# Altium schematic sheet S06S-Controller.SchDoc
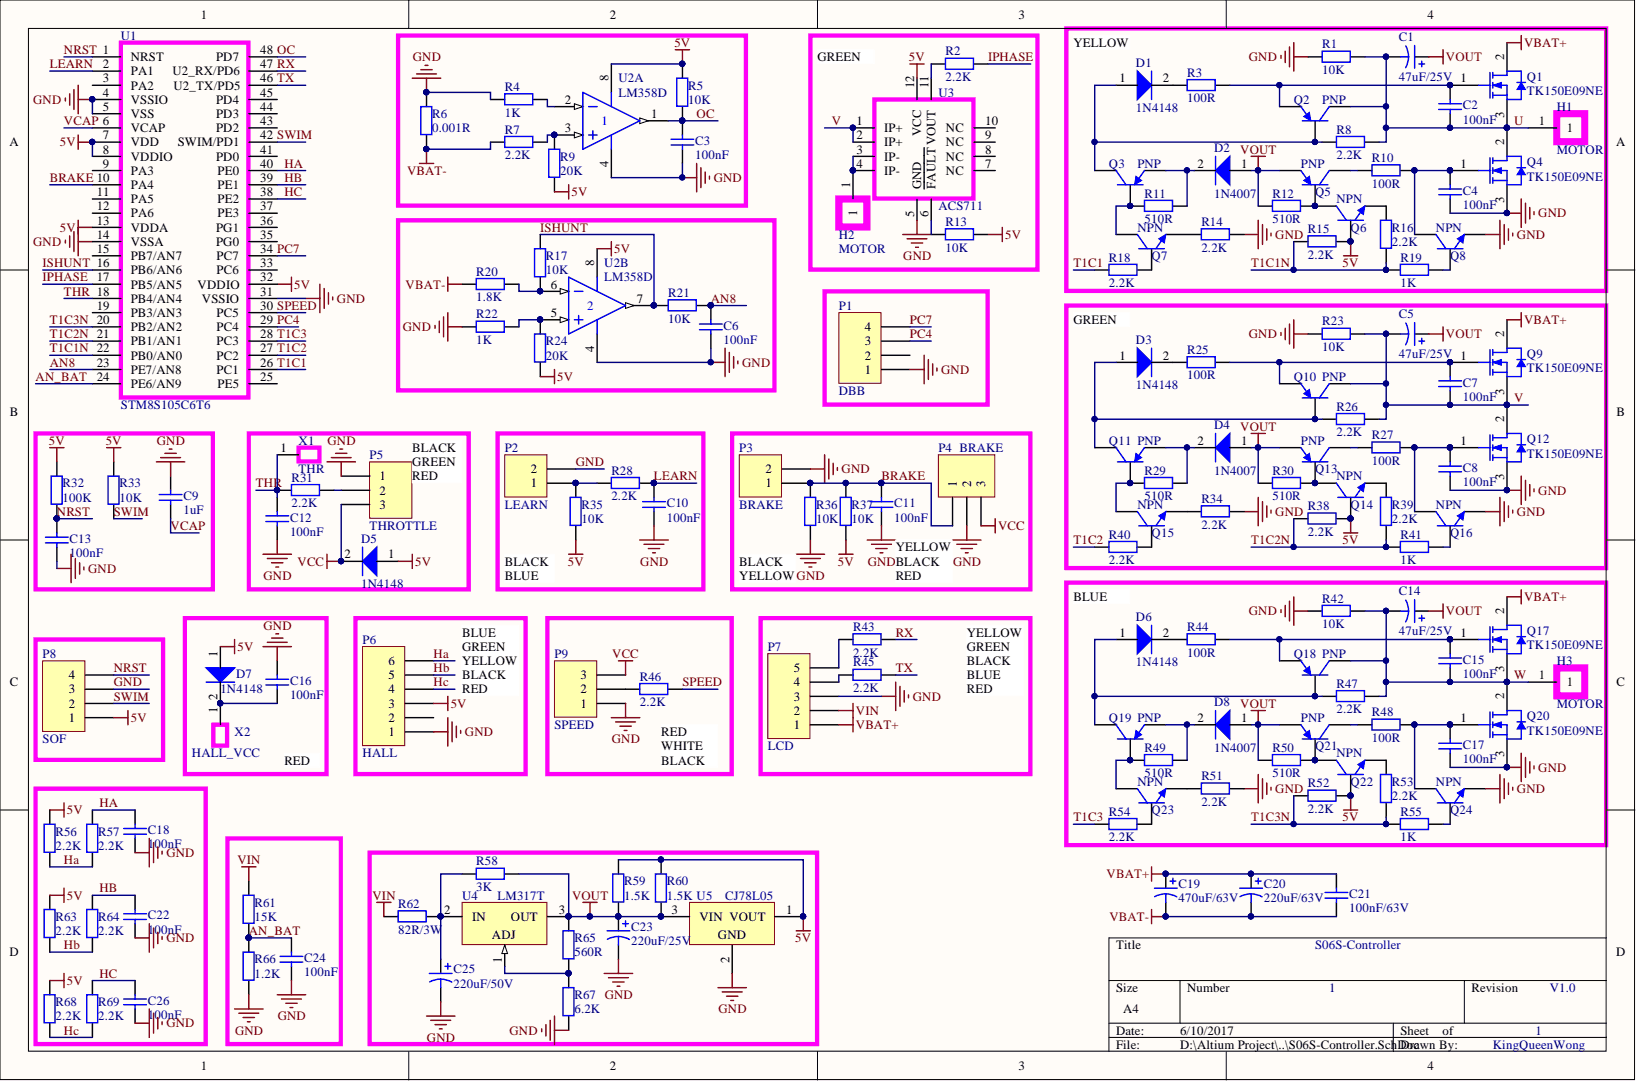
[diagram p0: S06S-Controller.SchDoc]

## Parts
C1  value 47uF/25V  [RB7.6-15]  (hidden comment: Cap Pol1)
C2  value 100nF  [0603C]  (hidden comment: Cap)
C3  value 100nF  [0603C]  (hidden comment: Cap)
C4  value 100nF  [0603C]  (hidden comment: Cap)
C5  value 47uF/25V  [RB7.6-15]  (hidden comment: Cap Pol1)
C6  value 100nF  [0603C]  (hidden comment: Cap)
C7  value 100nF  [0603C]  (hidden comment: Cap)
C8  value 100nF  [0603C]  (hidden comment: Cap)
C9  value 1uF  [0603C]  (hidden comment: Cap)
C10  value 100nF  [0603C]  (hidden comment: Cap)
C11  value 100nF  [0603C]  (hidden comment: Cap)
C12  value 100nF  [0603C]  (hidden comment: Cap)
C13  value 100nF  [0603C]  (hidden comment: Cap)
C14  value 47uF/25V  [RB7.6-15]  (hidden comment: Cap Pol1)
C15  value 100nF  [0603C]  (hidden comment: Cap)
C16  value 100nF  [0603C]  (hidden comment: Cap)
C17  value 100nF  [0603C]  (hidden comment: Cap)
C18  value 100nF  [0603C]  (hidden comment: Cap)
C19  value 470uF/63V  [RB7.6-15]  (hidden comment: Cap Pol1)
C20  value 220uF/63V  [RB7.6-15]  (hidden comment: Cap Pol1)
C21  value 100nF/63V  [0603C]  (hidden comment: Cap)
C22  value 100nF  [0603C]  (hidden comment: Cap)
C23  value 220uF/25V  [RB7.6-15]  (hidden comment: Cap Pol1)
C24  value 100nF  [0603C]  (hidden comment: Cap)
C25  value 220uF/50V  [RB7.6-15]  (hidden comment: Cap Pol1)
C26  value 100nF  [0603C]  (hidden comment: Cap)
D1  1N4148  [SOD80C]
D2  1N4007  [SOD80C]
D3  1N4148  [SOD80C]
D4  1N4007  [SOD80C]
D5  1N4148  [SOD80C]
D6  1N4148  [SOD80C]
D7  1N4148  [SOD80C]
D8  1N4007  [SOD80C]
H1  MOTOR  [MOTOR]
H2  MOTOR  [MOTOR]
H3  MOTOR  [MOTOR]
P1  DBB  [HDR1X4]
P2  LEARN  [HDR1X2]
P3  BRAKE  [HDR1X2]
P4  BRAKE  [HDR1X3]
P5  THROTTLE  [HDR1X3]
P6  HALL  [HDR1X6]
P7  LCD  [HDR1X5]
P8  SOF  [HDR1X4]
P9  SPEED  [HDR1X3]
Q1  TK150E09NE  [TO220ABN]
Q2  PNP  [SOT23]
Q3  PNP  [SOT23]
Q4  TK150E09NE  [TO220ABN]
Q5  PNP  [SOT23]
Q6  NPN  [SOT23]
Q7  NPN  [SOT23]
Q8  NPN  [SOT23]
Q9  TK150E09NE  [TO220ABN]
Q10  PNP  [SOT23]
Q11  PNP  [SOT23]
Q12  TK150E09NE  [TO220ABN]
Q13  PNP  [SOT23]
Q14  NPN  [SOT23]
Q15  NPN  [SOT23]
Q16  NPN  [SOT23]
Q17  TK150E09NE  [TO220ABN]
Q18  PNP  [SOT23]
Q19  PNP  [SOT23]
Q20  TK150E09NE  [TO220ABN]
Q21  PNP  [SOT23]
Q22  NPN  [SOT23]
Q23  NPN  [SOT23]
Q24  NPN  [SOT23]
R1  value 10K  [0603R]  (hidden comment: Res2)
R2  value 2.2K  [0603R]  (hidden comment: Res2)
R3  value 100R  [0603R]  (hidden comment: Res2)
R4  value 1K  [0603R]  (hidden comment: Res2)
R5  value 10K  [0603R]  (hidden comment: Res2)
R6  value 0.001R  [0603R]  (hidden comment: Res2)
R7  value 2.2K  [0603R]  (hidden comment: Res2)
R8  value 2.2K  [0603R]  (hidden comment: Res2)
R9  value 20K  [0603R]  (hidden comment: Res2)
R10  value 100R  [0603R]  (hidden comment: Res2)
R11  value 510R  [0603R]  (hidden comment: Res2)
R12  value 510R  [0603R]  (hidden comment: Res2)
R13  value 10K  [0603R]  (hidden comment: Res2)
R14  value 2.2K  [0603R]  (hidden comment: Res2)
R15  value 2.2K  [0603R]  (hidden comment: Res2)
R16  value 2.2K  [0603R]  (hidden comment: Res2)
R17  value 10K  [0603R]  (hidden comment: Res2)
R18  value 2.2K  [0603R]  (hidden comment: Res2)
R19  value 1K  [0603R]  (hidden comment: Res2)
R20  value 1.8K  [0603R]  (hidden comment: Res2)
R21  value 10K  [0603R]  (hidden comment: Res2)
R22  value 1K  [0603R]  (hidden comment: Res2)
R23  value 10K  [0603R]  (hidden comment: Res2)
R24  value 20K  [0603R]  (hidden comment: Res2)
R25  value 100R  [0603R]  (hidden comment: Res2)
R26  value 2.2K  [0603R]  (hidden comment: Res2)
R27  value 100R  [0603R]  (hidden comment: Res2)
R28  value 2.2K  [0603R]  (hidden comment: Res2)
R29  value 510R  [0603R]  (hidden comment: Res2)
R30  value 510R  [0603R]  (hidden comment: Res2)
R31  value 2.2K  [0603R]  (hidden comment: Res2)
R32  value 100K  [0603R]  (hidden comment: Res2)
R33  value 10K  [0603R]  (hidden comment: Res2)
R34  value 2.2K  [0603R]  (hidden comment: Res2)
R35  value 10K  [0603R]  (hidden comment: Res2)
R36  value 10K  [0603R]  (hidden comment: Res2)
R37  value 10K  [0603R]  (hidden comment: Res2)
R38  value 2.2K  [0603R]  (hidden comment: Res2)
R39  value 2.2K  [0603R]  (hidden comment: Res2)
R40  value 2.2K  [0603R]  (hidden comment: Res2)
R41  value 1K  [0603R]  (hidden comment: Res2)
R42  value 10K  [0603R]  (hidden comment: Res2)
R43  value 2.2K  [0603R]  (hidden comment: Res2)
R44  value 100R  [0603R]  (hidden comment: Res2)
R45  value 2.2K  [0603R]  (hidden comment: Res2)
R46  value 2.2K  [0603R]  (hidden comment: Res2)
R47  value 2.2K  [0603R]  (hidden comment: Res2)
R48  value 100R  [0603R]  (hidden comment: Res2)
R49  value 510R  [0603R]  (hidden comment: Res2)
R50  value 510R  [0603R]  (hidden comment: Res2)
R51  value 2.2K  [0603R]  (hidden comment: Res2)
R52  value 2.2K  [0603R]  (hidden comment: Res2)
R53  value 2.2K  [0603R]  (hidden comment: Res2)
R54  value 2.2K  [0603R]  (hidden comment: Res2)
R55  value 1K  [0603R]  (hidden comment: Res2)
R56  value 2.2K  [0603R]  (hidden comment: Res2)
R57  value 2.2K  [0603R]  (hidden comment: Res2)
R58  value 3K  [0603R]  (hidden comment: Res2)
R59  value 1.5K  [0603R]  (hidden comment: Res2)
R60  value 1.5K  [0603R]  (hidden comment: Res2)
R61  value 15K  [0603R]  (hidden comment: Res2)
R62  value 82R/3W  [0603R]  (hidden comment: Res2)
R63  value 2.2K  [0603R]  (hidden comment: Res2)
R64  value 2.2K  [0603R]  (hidden comment: Res2)
R65  value 560R  [0603R]  (hidden comment: Res2)
R66  value 1.2K  [0603R]  (hidden comment: Res2)
R67  value 6.2K  [0603R]  (hidden comment: Res2)
R68  value 2.2K  [0603R]  (hidden comment: Res2)
R69  value 2.2K  [0603R]  (hidden comment: Res2)
U1  STM8S105C6T6  [TQFP-48]
U2  LM358D  [751-02_N]  (parts A,B)
U3  ACS711  [QFN12]
U4  LM317T  [TO220ABN]
U5  CJ78L05  [TO-92]
X1  THR  [HDR1X1]
X2  HALL_VCC  [HDR1X1]

## Nets
5V: D5.1 D7.1 P6.3 P8.1 Q6.2 Q14.2 Q22.2 R5.2 R9.1 R13.1 R15.2 R24.1 R32.1 R33.1 R35.1 R37.1 R38.2 R52.2 R56.1 R59.2 R60.2 R63.1 R68.1 U1.7(VDD) U1.8(VDDIO) U1.13(VDDA) U1.32(VDDIO) U2.8 U3.12(VCC) U5.1
VBAT+: C19.1 C20.1 C21.1 P7.1 Q1.2 Q9.2 Q17.2
VBAT-: C19.2 C20.2 C21.2 R6.2 R7.2 R20.2
VCC: D5.2 P4.3 P5.3 P9.3
VIN: P7.2 R61.2 R62.1
VOUT: C1.1 C5.1 C14.1 C23.1 D2.1 D4.1 D8.1 Q5.3 Q13.3 Q21.3 R12.2 R30.2 R50.2 R58.1 R59.1 R60.1 R65.1 U4.3 U5.3
AN8: C6.1 R21.2 U1.23(PE7/AN8)
AN_BAT: C24.1 R61.1 R66.2 U1.24(PE6/AN9)
BRAKE: C11.1 P3.1 P4.1 R36.2 R37.2 U1.10(PA4)
GND: C3.2 C4.2 C6.2 C8.2 C9.1 C10.2 C11.2 C12.2 C13.2 C16.2 C17.2 C18.2 C22.2 C23.2 C24.2 C25.2 C26.2 P1.1 P2.2 P3.2 P4.2 P5.1 P6.1 P7.3 P8.3 P9.1 Q4.3 Q8.3 Q12.3 Q16.3 Q20.3 Q24.3 R1.2 R4.2 R6.1 R14.1 R22.2 R23.2 R34.1 R36.1 R42.2 R51.1 R66.1 R67.2 U1.4(VSSIO) U1.5(VSS) U1.14(VSSA) U1.31(VSSIO) U2.4 U3.5(GND) U5.2
Ha: C18.1 P6.6 R56.2 R57.1 R57.2 U1.40(PE0)
Hb: C22.1 P6.5 R63.2 R64.1 R64.2 U1.39(PE1)
Hc: C26.1 P6.4 R68.2 R69.1 R69.2 U1.38(PE2)
IPHASE: R2.1 U1.17(PB5/AN5)
ISHUNT: R17.2 R21.1 U1.16(PB6/AN6) U2.7
LEARN: C10.1 R28.2 U1.2(PA1)
NRST: C13.1 P8.4 R32.2 U1.1(NRST)
OC: C3.1 R5.1 U1.48(PD7) U2.1
PC4: P1.3 U1.29(PC4)
PC7: P1.4 U1.34(PC7)
RX: R43.2 U1.47(U2_RX/PD6)
SPEED: R46.2 U1.30(PC5)
SWIM: P8.2 R33.2 U1.42(SWIM/PD1)
T1C1: R18.1 U1.26(PC1)
T1C1N: R15.1 R16.1 R19.1 U1.22(PB0/AN0)
T1C2: R40.1 U1.27(PC2)
T1C2N: R38.1 R39.1 R41.1 U1.21(PB1/AN1)
T1C3: R54.1 U1.28(PC3)
T1C3N: R52.1 R53.1 R55.1 U1.20(PB2/AN2)
THR: C12.1 R31.1 U1.18(PB4/AN4) X1.1
TX: R45.2 U1.46(U2_TX/PD5)
U: C1.2 C2.2 H1.1 Q1.3 Q2.1 Q4.2 R1.1 R8.2
V: C5.2 C7.2 Q9.3 Q10.1 Q12.2 R23.1 R26.2 U3.1(IP+) U3.2(IP+)
VCAP: C9.2 U1.6(VCAP)
W: C14.2 C15.2 H3.1 Q17.3 Q18.1 Q20.2 R42.1 R47.2
NetC2_1: C2.1 Q1.1 Q2.3 R3.1
NetC4_1: C4.1 Q4.1 Q8.1 R10.1
NetC7_1: C7.1 Q9.1 Q10.3 R25.1
NetC8_1: C8.1 Q12.1 Q16.1 R27.1
NetC15_1: C15.1 Q17.1 Q18.3 R44.1
NetC16_1: C16.1 D7.2 X2.1
NetC17_1: C17.1 Q20.1 Q24.1 R48.1
NetC25_1: C25.1 R58.2 R62.2 U4.2
NetD1_1: D1.1 Q2.2 Q3.1 R8.1
NetD1_2: D1.2 R3.2
NetD2_2: D2.2 Q3.3 R11.1
NetD3_1: D3.1 Q10.2 Q11.1 R26.1
NetD3_2: D3.2 R25.2
NetD4_2: D4.2 Q11.3 R29.1
NetD6_1: D6.1 Q18.2 Q19.1 R47.1
NetD6_2: D6.2 R44.2
NetD8_2: D8.2 Q19.3 R49.1
NetH2_1: H2.1 U3.3(IP-) U3.4(IP-)
NetP2_1: P2.1 R28.1 R35.2
NetP5_2: P5.2 R31.2
NetP7_4: P7.4 R45.1
NetP7_5: P7.5 R43.1
NetP9_2: P9.2 R46.1
NetQ3_2: Q3.2 Q7.1 R11.2
NetQ5_1: Q5.1 R10.2
NetQ5_2: Q5.2 Q6.1 R12.1
NetQ6_3: Q6.3 R16.2
NetQ7_2: Q7.2 R18.2
NetQ7_3: Q7.3 R14.2
NetQ8_2: Q8.2 R19.2
NetQ11_2: Q11.2 Q15.1 R29.2
NetQ13_1: Q13.1 R27.2
NetQ13_2: Q13.2 Q14.1 R30.1
NetQ14_3: Q14.3 R39.2
NetQ15_2: Q15.2 R40.2
NetQ15_3: Q15.3 R34.2
NetQ16_2: Q16.2 R41.2
NetQ19_2: Q19.2 Q23.1 R49.2
NetQ21_1: Q21.1 R48.2
NetQ21_2: Q21.2 Q22.1 R50.1
NetQ22_3: Q22.3 R53.2
NetQ23_2: Q23.2 R54.2
NetQ23_3: Q23.3 R51.2
NetQ24_2: Q24.2 R55.2
NetR2_2: R2.2 U3.11(VOUT)
NetR4_1: R4.1 U2.2
NetR7_1: R7.1 R9.2 U2.3
NetR13_2: R13.2 U3.6(FAULT)
NetR17_1: R17.1 R20.1 U2.6
NetR22_1: R22.1 R24.2 U2.5
NetR65_2: R65.2 R67.1 U4.1

Not connected: P1 2; P6 2; U1 3(PA2) 9(PA3) 11(PA5) 12(PA6) 15(PB7/AN7) 19(PB3/AN3) 25(PE5) 33(PC6) 35(PG0) 36(PG1) 37(PE3) 41(PD0) 43(PD2) 44(PD3) 45(PD4); U3 7(NC) 8(NC) 9(NC) 10(NC)

## Library data of the parts
C1 C5 C14 C19 C20 C23 C25 = Cap Pol1: Polarized Capacitor (Radial); Component Kind: Standard; LatestRevisionDate: 17-Jul-2002; LatestRevisionNote: Re-released for DXP Platform.; Library Name: Miscellaneous Devices.IntLib; PackageDescription: Polarized Capacitor (Radial); 2 Leads; PackageReference: RB7.6-15; Published: 8-Jun-2000; Signal Integrity: Cap Pol; Simulation: CAP; Publisher: Altium Limited
C2-C4 C6-C13 C15-C18 C21 C22 C24 C26 = Cap: Capacitor; Component Kind: Standard; LatestRevisionDate: 17-Jul-2002; LatestRevisionNote: Re-released for DXP Platform.; Library Name: Miscellaneous Devices.IntLib; PackageDescription: Radial Cap, Thru-Hole; 2 Leads; 0.3 in Pin Spacing; PackageReference: RAD-0.3; Published: 8-Jun-2000; Signal Integrity: Cap; Simulation: CAP; Publisher: Altium Limited
D2 D4 D8 = 1N4148: Component Kind: Standard; Description: 1N4148; Library Name: Schlib.SchLib
P1 P8 = Header 4: Header, 4-Pin; Component Kind: Standard; LatestRevisionDate: 17-Jul-2002; LatestRevisionNote: Re-released for DXP Platform.; Library Name: Miscellaneous Connectors.IntLib; Signal Integrity: Connector; Publisher: Altium Limited
P2 P3 = Header 2: Header, 2-Pin; Component Kind: Standard; LatestRevisionDate: 17-Jul-2002; LatestRevisionNote: Re-released for DXP Platform.; Library Name: Miscellaneous Connectors.IntLib; Signal Integrity: Connector; Publisher: Altium Limited
P4 P5 P9 = Header 3: Header, 3-Pin; Component Kind: Standard; LatestRevisionDate: 17-Jul-2002; LatestRevisionNote: Re-released for DXP Platform.; Library Name: Miscellaneous Connectors.IntLib; Signal Integrity: Connector; Publisher: Altium Limited
P6 = Header 6: Header, 6-Pin; Component Kind: Standard; LatestRevisionDate: 17-Jul-2002; LatestRevisionNote: Re-released for DXP Platform.; Library Name: Miscellaneous Connectors.IntLib; Signal Integrity: Connector; Publisher: Altium Limited
P7 = Header 5: Header, 5-Pin; Component Kind: Standard; LatestRevisionDate: 17-Jul-2002; LatestRevisionNote: Re-released for DXP Platform.; Library Name: Miscellaneous Connectors.IntLib; Signal Integrity: Connector; Publisher: Altium Limited
Q2 Q3 Q5 Q10 Q11 Q13 Q18 Q19 Q21 = PNP: PNP Bipolar Transistor; Component Kind: Standard; LatestRevisionDate: 29-May-2009; LatestRevisionNote: IPC-7351 Footprint Added.; Library Name: Schlib.SchLib; PackageDescription: 3-Pin SOT-23 Package 0.95 mm Pitch, 2.4 mm Lead Span; PackageReference: SOT-23B; PackageVersion: Aug-1999; Published: 8-Jun-2000; Signal Integrity: PNP; Simulation: PNP; Publisher: Altium Limited
Q6-Q8 Q14-Q16 Q22-Q24 = NPN: NPN Bipolar Transistor; Code_JEDEC: TO-226-AA; Component Kind: Standard; LatestRevisionDate: 17-Jul-2002; LatestRevisionNote: Re-released for DXP Platform.; Library Name: Schlib.SchLib; PackageDescription: TO, Flat Index; 3 In-Line, Axial Leads; Body Dia. 4.8mm; Leads 0.55 x 0.51 mm (max); PackageReference: TO-226-AA; Published: 8-Jun-2000; Signal Integrity: NPN; Simulation: NPN; Publisher: Altium Limited
R1-R69 = Res2: Resistor; Component Kind: Standard; LatestRevisionDate: 17-Jul-2002; LatestRevisionNote: Re-released for DXP Platform.; Library Name: Miscellaneous Devices.IntLib; PackageDescription: Axial Device, Thru-Hole; 2 Leads; 0.4 in Pin Spacing; PackageReference: AXIAL-0.4; Published: 8-Jun-2000; Signal Integrity: Res; Simulation: RESISTOR; Publisher: Altium Limited
U2 = LM358D: Dual Low-Power Operational Amplifier; Code_IPC: SOIC127P600-8; Code_JEDEC: MS-012-AA; Component Kind: Standard; ComponentLink1Description: Manufacturer Link; ComponentLink1URL: http://www.onsemi.com/site/products/parametrics/0,4446,251,00.html; ComponentLink2Description: Datasheet; ComponentLink2URL: http://www.onsemi.com/pub/Collateral/LM358-D.PDF; DatasheetVersion: 1988; LatestRevisionDate: 13-Apr-2006; LatestRevisionNote: IPC-7351 Footprint Added.; Library Name: Motorola Amplifier Operational Amplifier.IntLib; PackageDescription: 8-Pin Small Outline Integrated Circuit 1.27 mm Pitch; PackageReference: 751-02; PCB3D: 751-02; Published: 17-Jul-2002; Publisher: Altium Limited
U4 = LM317T: 1.2V to 37V Voltage Regulator; Note: Tab is connected to pin 3 (OUT).; Component Kind: Standard; ComponentLink1Description: Manufacturer Link; ComponentLink1URL: http://www.st.com/; ComponentLink2Description: Datasheet; ComponentLink2URL: http://www.st.com/stonline/products/literature/ds/2154/lm117.pdf; DatasheetVersion: Nov-1999; LatestRevisionDate: 17-Jul-2002; LatestRevisionNote: Re-released for DXP Platform.; Library Name: Schlib.SchLib; PackageDescription: TO, Thru-Hole, Vertical, Heatsink Mounted; 3 In-Line Leads; Pitch 2.54 mm; Pin sequence 1, 3, 2; PackageReference: TO220ABN; PackageVersion: Jun-1998; Published: 24-Aug-2001; Publisher: Altium Limited
U5 = L78L05ACZ-AP: Positive Voltage Regulator, 5V, 3-Pin TO-92; Component Kind: Standard; ComponentLink1Description: Manufacturer URL; ComponentLink1URL: http://www.st.com; ComponentLink2Description: Datasheet; ComponentLink2URL: http://www.st.com/internet/com/TECHNICAL_RESOURCES/TECHNICAL_LITERATURE/DATASHEET/CD00000446.pdf; DatasheetVersion: Feb-2011; Library Name: STMicroelectronics Power Management Linear Regulator.IntLib; PackageDescription: TO, Flat Index; 3 In-Line, Axial Leads; Body Dia. 4.7mm; Lead Dia. 0.56 mm (max); PackageReference: TO-92; PackageVersion: 2011; PartNumber: L78L05ACZ-AP
X1 X2 = 1P: Component Kind: Standard; Description: 1P; Library Name: Schlib.SchLib
D1 D3 D5-D7 = 1N4148: Component Kind: Standard; Description: 1N4148; Library Name: Schlib.SchLib
H1-H3 = MOTOR: Component Kind: Standard; Description: MOTOR; Library Name: Schlib.SchLib
Q1 Q4 Q9 Q12 Q17 Q20 = TK150E09NE: Component Kind: Standard; Description: TK150E09NE; Library Name: Schlib.SchLib
U1 = STM8S105C6T6: Component Kind: Standard; Description: STM8S105C6T6; Library Name: Schlib.SchLib
U3 = ACS711: Component Kind: Standard; Description: ACS711; Library Name: Schlib.SchLib
